# Altium schematic sheet floppy interface.SchDoc
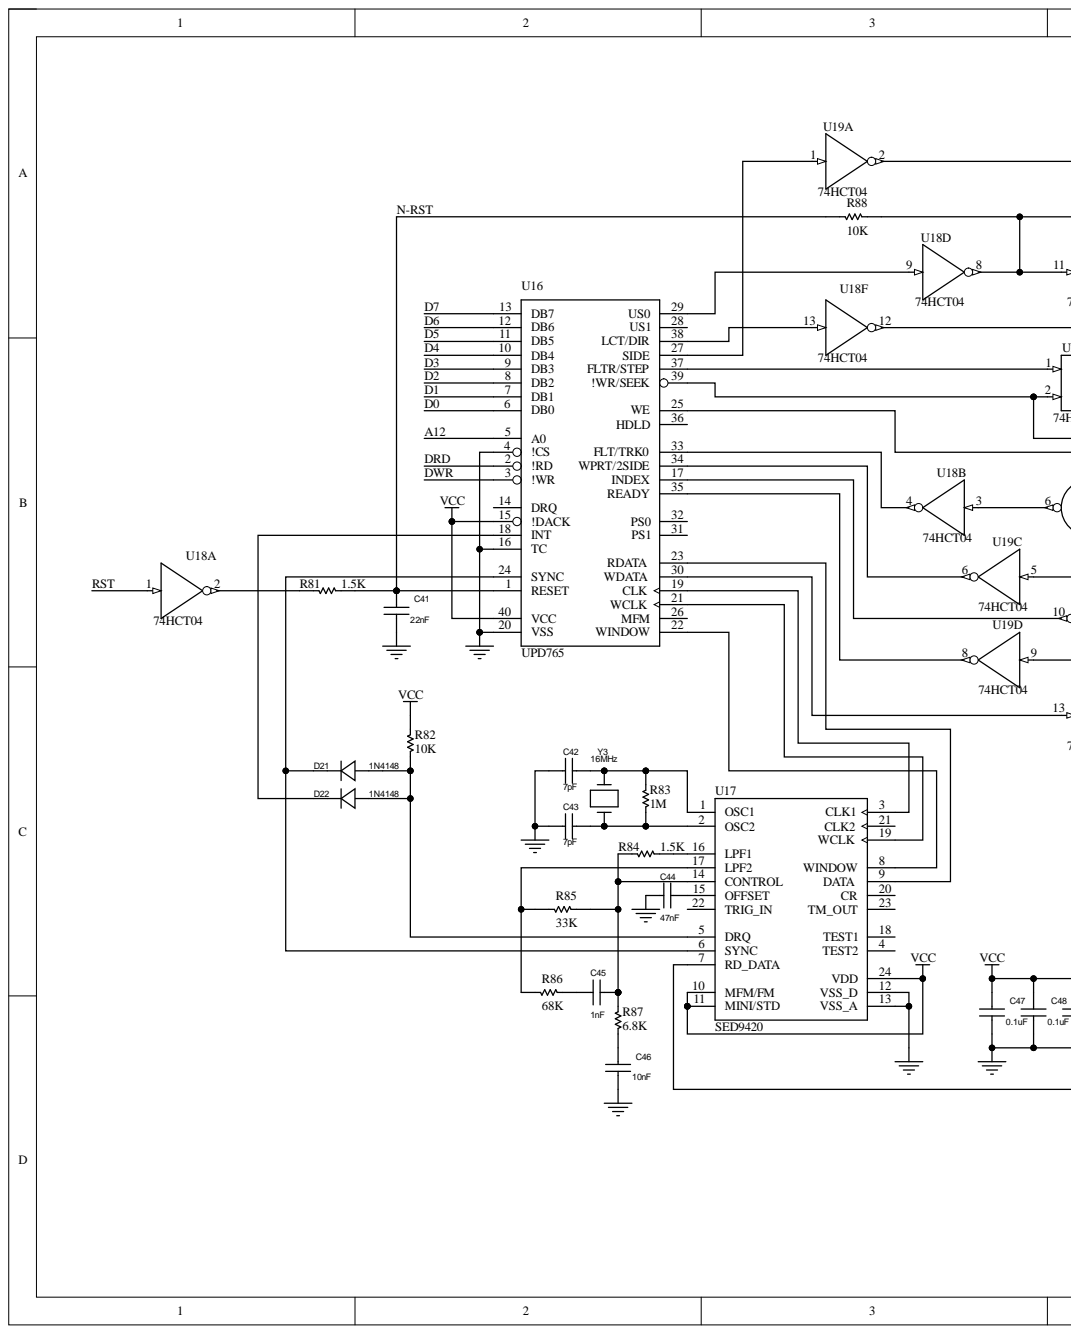
[diagram p0: floppy interface.SchDoc, left]
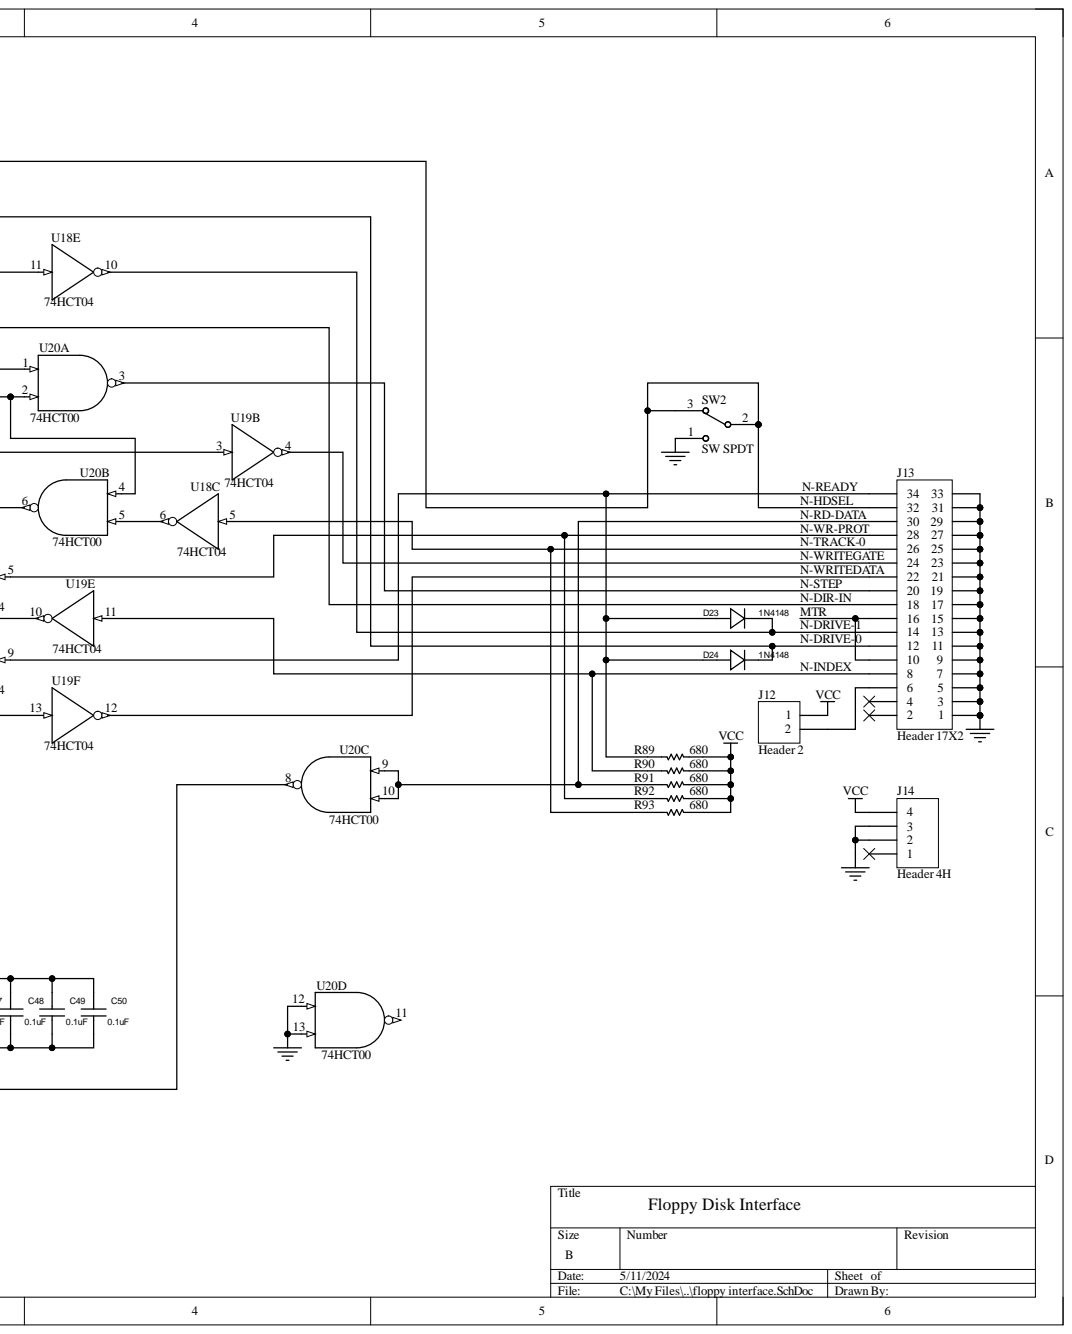
[diagram p1: floppy interface.SchDoc, right]

## Parts
C41  22nF  [RAD/.200X.100/LS.100/.031]
C42  7pF  [RAD/.200X.100/LS.100/.031]
C43  7pF  [RAD/.200X.100/LS.100/.031]
C44  47nF  [RAD/.200X.100/LS.100/.031]
C45  1nF  [RAD/.200X.100/LS.100/.031]
C46  10nF  [RAD/.200X.100/LS.100/.031]
C47  0.1uF  [RAD/.200X.100/LS.100/.031]
C48  0.1uF  [RAD/.200X.100/LS.100/.031]
C49  0.1uF  [RAD/.200X.100/LS.100/.031]
C50  0.1uF  [RAD/.200X.100/LS.100/.031]
D21  1N4148  [DAX2/.300X.050/.028]
D22  1N4148  [DAX2/.300X.050/.028]
D23  1N4148  [DAX2/.300X.050/.028]
D24  1N4148  [DAX2/.300X.050/.028]
J12  Header 2  [HDR1X2]
J13  Header 17X2  [HDR2X17 RIGHT ANGLE]
J14  Header 4H  [HDR1X4H]
R81  1.5K  [AX/.350X.100/.034]
R82  10K  [AX/.350X.100/.034]
R83  1M  [AX/.350X.100/.034]
R84  1.5K  [AX/.350X.100/.034]
R85  33K  [AX/.350X.100/.034]
R86  68K  [AX/.350X.100/.034]
R87  6.8K  [AX/.350X.100/.034]
R88  10K  [AX/.350X.100/.034]
R89  680  [AX/.350X.100/.034]
R90  680  [AX/.350X.100/.034]
R91  680  [AX/.350X.100/.034]
R92  680  [AX/.350X.100/.034]
R93  680  [AX/.350X.100/.034]
SW2  SW SPDT  [SW-SPDT - MHSS1105]
U16  UPD765  [DIP.100/40/W.600/L2.025]
U17  SED9420  [DIP.100/24/W.600/L1.200]
U18  74HCT04  [DIP.100/14/W.300/L.700]  (parts A,B,C,D,E,F)
U19  74HCT04  [DIP.100/14/W.300/L.700]  (parts A,B,C,D,E,F)
U20  74HCT00  [DIP.100/14/W.300/L.700]  (parts A,B,C,D)
Y3  16MHz  [RAD/.300X.125/LS.200/.031]

## Nets
GND: C41.2 C42.1 C43.1 C44.2 C46.2 C47.2 C48.2 C49.2 C50.2 J13.1 J13.3 J13.5 J13.7 J13.9 J13.11 J13.13 J13.15 J13.17 J13.19 J13.21 J13.23 J13.25 J13.27 J13.29 J13.31 J13.33 J14.2 J14.3 SW2.1 U16.4(!CS) U16.16(TC) U16.20(VSS) U17.12(VSS_D) U17.13(VSS_A) U18.7 U19.7 U20.7 U20.12 U20.13
VCC: C47.1 C48.1 C49.1 C50.1 J12.1 J14.4 R82.2 R89.1 R90.1 R91.1 R92.1 R93.1 U16.15(!DACK) U16.40(VCC) U17.10(MFM/FM) U17.11(MINI/STD) U17.24(VDD) U18.14 U19.14 U20.14
A12: U16.5(A0)
D0: U16.6(DB0)
D1: U16.7(DB1)
D2: U16.8(DB2)
D3: U16.9(DB3)
D4: U16.10(DB4)
D5: U16.11(DB5)
D6: U16.12(DB6)
D7: U16.13(DB7)
DRD: U16.2(!RD)
DWR: U16.3(!WR)
MTR: J13.10 J13.16
N-DIR-IN: J13.18 U18.12
N-DRIVE-0: D24.2 J13.12 R88.1 U18.8 U18.11
N-DRIVE-1: D23.2 J13.14 U18.10
N-HDSEL: J13.32 SW2.2 SW2.3 U19.2
N-INDEX: J13.8 R90.2 U19.11
N-RD-DATA: J13.30 R91.2 U20.9 U20.10
N-READY: D23.1 D24.1 J13.34 R89.2 U19.9
N-RST: C41.1 R81.1 R88.2 U16.1(RESET)
N-STEP: J13.20 U20.3
N-TRACK-0: J13.26 R93.2 U18.5
N-WR-PROT: J13.28 R92.2 U19.5
N-WRITEDATA: J13.22 U19.12
N-WRITEGATE: J13.24 U19.4
RST: U18.1
NetC42_2: C42.2 R83.1 U17.1(OSC1) Y3.2
NetC43_2: C43.2 R83.2 U17.2(OSC2) Y3.1
NetC44_1: C44.1 U17.15(OFFSET)
NetC45_1: C45.1 R86.2
NetC45_2: C45.2 R84.2 R85.1 R87.1 U17.14(CONTROL)
NetC46_1: C46.1 R87.2
NetD21_1: D21.1 D22.1 R82.1 U17.5(DRQ)
NetD21_2: D21.2 U16.24(SYNC) U17.6(SYNC)
NetD22_2: D22.2 U16.18(INT)
NetJ12_2: J12.2 J13.6
NetR81_2: R81.2 U18.2
NetR84_1: R84.1 U17.16(LPF1)
NetR85_2: R85.2 R86.1 U17.17(LPF2)
NetU16_17: U16.17(INDEX) U19.10
NetU16_19: U16.19(CLK) U17.3(CLK1)
NetU16_21: U16.21(WCLK) U17.19(WCLK)
NetU16_22: U16.22(WINDOW) U17.8(WINDOW)
NetU16_23: U16.23(RDATA) U17.9(DATA)
NetU16_25: U16.25(WE) U19.3
NetU16_27: U16.27(SIDE) U19.1
NetU16_29: U16.29(US0) U18.9
NetU16_30: U16.30(WDATA) U19.13
NetU16_33: U16.33(FLT/TRK0) U18.4
NetU16_34: U16.34(WPRT/2SIDE) U19.6
NetU16_35: U16.35(READY) U19.8
NetU16_37: U16.37(FLTR/STEP) U20.1
NetU16_38: U16.38(LCT/DIR) U18.13
NetU16_39: U16.39(!WR/SEEK) U20.2 U20.4
NetU17_7: U17.7(RD_DATA) U20.8
NetU18_3: U18.3 U20.6
NetU18_6: U18.6 U20.5

Not connected: J13 2 4; J14 1; U16 14(DRQ) 26(MFM) 28(US1) 31(PS1) 32(PS0) 36(HDLD); U17 4(TEST2) 18(TEST1) 20(CR) 21(CLK2) 22(TRIG_IN) 23(TM_OUT); U20 11

## Library data of the parts
C41-C50 = CAP NP: COMPFIXED: NO; COMPGROUP: 0; COMPHEIGHT: 0.000; COMPLOC: [6275.000,975.000]; COMPSIDE: TOP; FPLIST: RAD/.150X.100/LS.100/.031; COMPKEY: NO; COMPLOCKED: NO; Component Kind: Standard; COMPROT: 0; DECOUPLER: NO; MEMORY: NO
D21-D24 = 1N4148: COMPFIXED: NO; COMPGROUP: 0; COMPHEIGHT: 0.000; COMPLOC: [7450.000,2300.000]; COMPROT: 90; COMPSIDE: TOP; COMPKEY: NO; COMPLOCKED: NO; Component Kind: Standard; DECOUPLER: NO; FPLIST: DAX2/.300X.050/.028; MEMORY: NO
J12 = Header 2: Header, 2-Pin; Component Kind: Standard; LatestRevisionDate: 17-Jul-2002; LatestRevisionNote: Re-released for DXP Platform.; Library Name: Miscellaneous Connectors.IntLib; Signal Integrity: Connector; Publisher: Altium Limited
J13 = Header 17X2: Header, 17-Pin, Dual row; Component Kind: Standard; LatestRevisionDate: 17-Jul-2002; LatestRevisionNote: Re-released for DXP Platform.; Library Name: Miscellaneous Connectors.IntLib; Signal Integrity: Connector; Publisher: Altium Limited
J14 = Header 4H: Header, 4-Pin, Right Angle; Component Kind: Standard; LatestRevisionDate: 17-Jul-2002; LatestRevisionNote: Re-released for DXP Platform.; Library Name: Miscellaneous Connectors.IntLib; Signal Integrity: Connector; Publisher: Altium Limited
R81-R93 = Res1: Resistor; Component Kind: Standard; LatestRevisionDate: 17-Jul-2002; LatestRevisionNote: Re-released for DXP Platform.; Library Name: Miscellaneous Devices.IntLib; PackageReference: AXIAL-0.3; Published: 8-Jun-2000; Signal Integrity: Res; Simulation: RESISTOR; Publisher: Altium Limited
  R81 R84: Value: 1.5K
  R82 R88: Value: 10K
  R83: Value: 1M
  R85: Value: 33K
  R86: Value: 68K
  R87: Value: 6.8K
  R89-R93: Value: 680
SW2 = SW SPDT: SPDT Subminiature Toggle Switch, Right Angle Mounting; Component Kind: Standard; ComponentLink1Description: Manufacturer Link; ComponentLink1URL: http://www.apem.com; DatasheetVersion: 05-Dec-2000; LatestRevisionDate: 17-Dec-2003; LatestRevisionNote: Schematic revised, PCB Footprint replaced.; PackageReference: TL36WW15050; Published: 24-Mar-1999; Library Name: Retro Computer.SchLib; Publisher: Altium Limited
U18 U19 = 74HC04: IO_LEVEL: 0; PSpiceTemplate: X^@REFDES %A %Y %VCC %GND @MODEL PARAMS:\n+ IO_LEVEL=@IO_LEVEL MNTYMXDLY=@MNTYMXDLY; Component Kind: Standard; MNTYMXDLY: 0; Simulation: 74HC04; Library Name: floppy_interface.SchLib
U20 = 74HC00: IO_LEVEL: 0; PSpiceTemplate: X^@REFDES %A %B %Y %VCC %GND @MODEL PARAMS:\n+ IO_LEVEL=@IO_LEVEL MNTYMXDLY=@MNTYMXDLY; Component Kind: Standard; MNTYMXDLY: 0; Simulation: 74HC00; Library Name: floppy_interface.SchLib
Y3 = CRYSTAL: COMPFIXED: NO; COMPGROUP: 0; COMPHEIGHT: 0.000; COMPLOC: [6575.317,975.317]; COMPSIDE: TOP; COMPKEY: NO; COMPLOCKED: NO; Component Kind: Standard; COMPROT: 0; DECOUPLER: NO; MEMORY: NO
U16 = UPD765: Component Kind: Standard; Library Name: 8Bit_Parts
U17 = SED9420: Component Kind: Standard; Library Name: 8Bit_Parts (2)
